# Altium schematic sheet Connectors.SchDoc
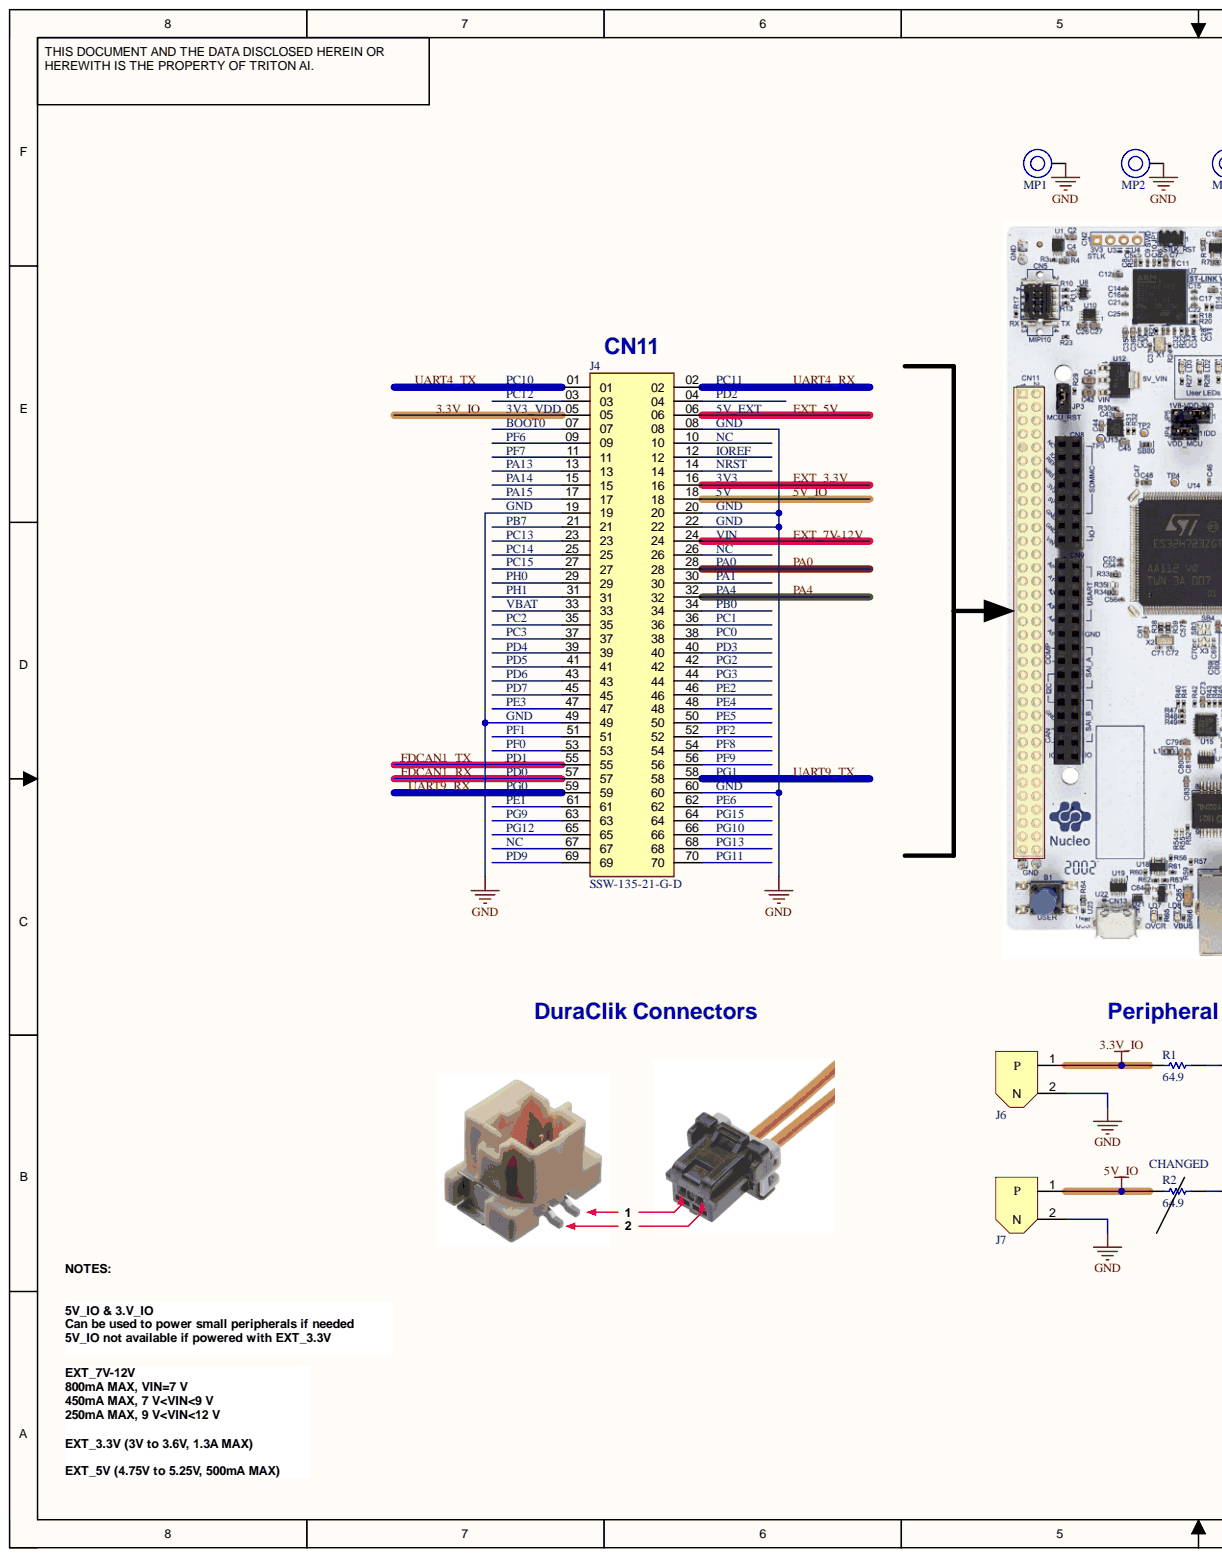
[diagram p0: Connectors.SchDoc, left]
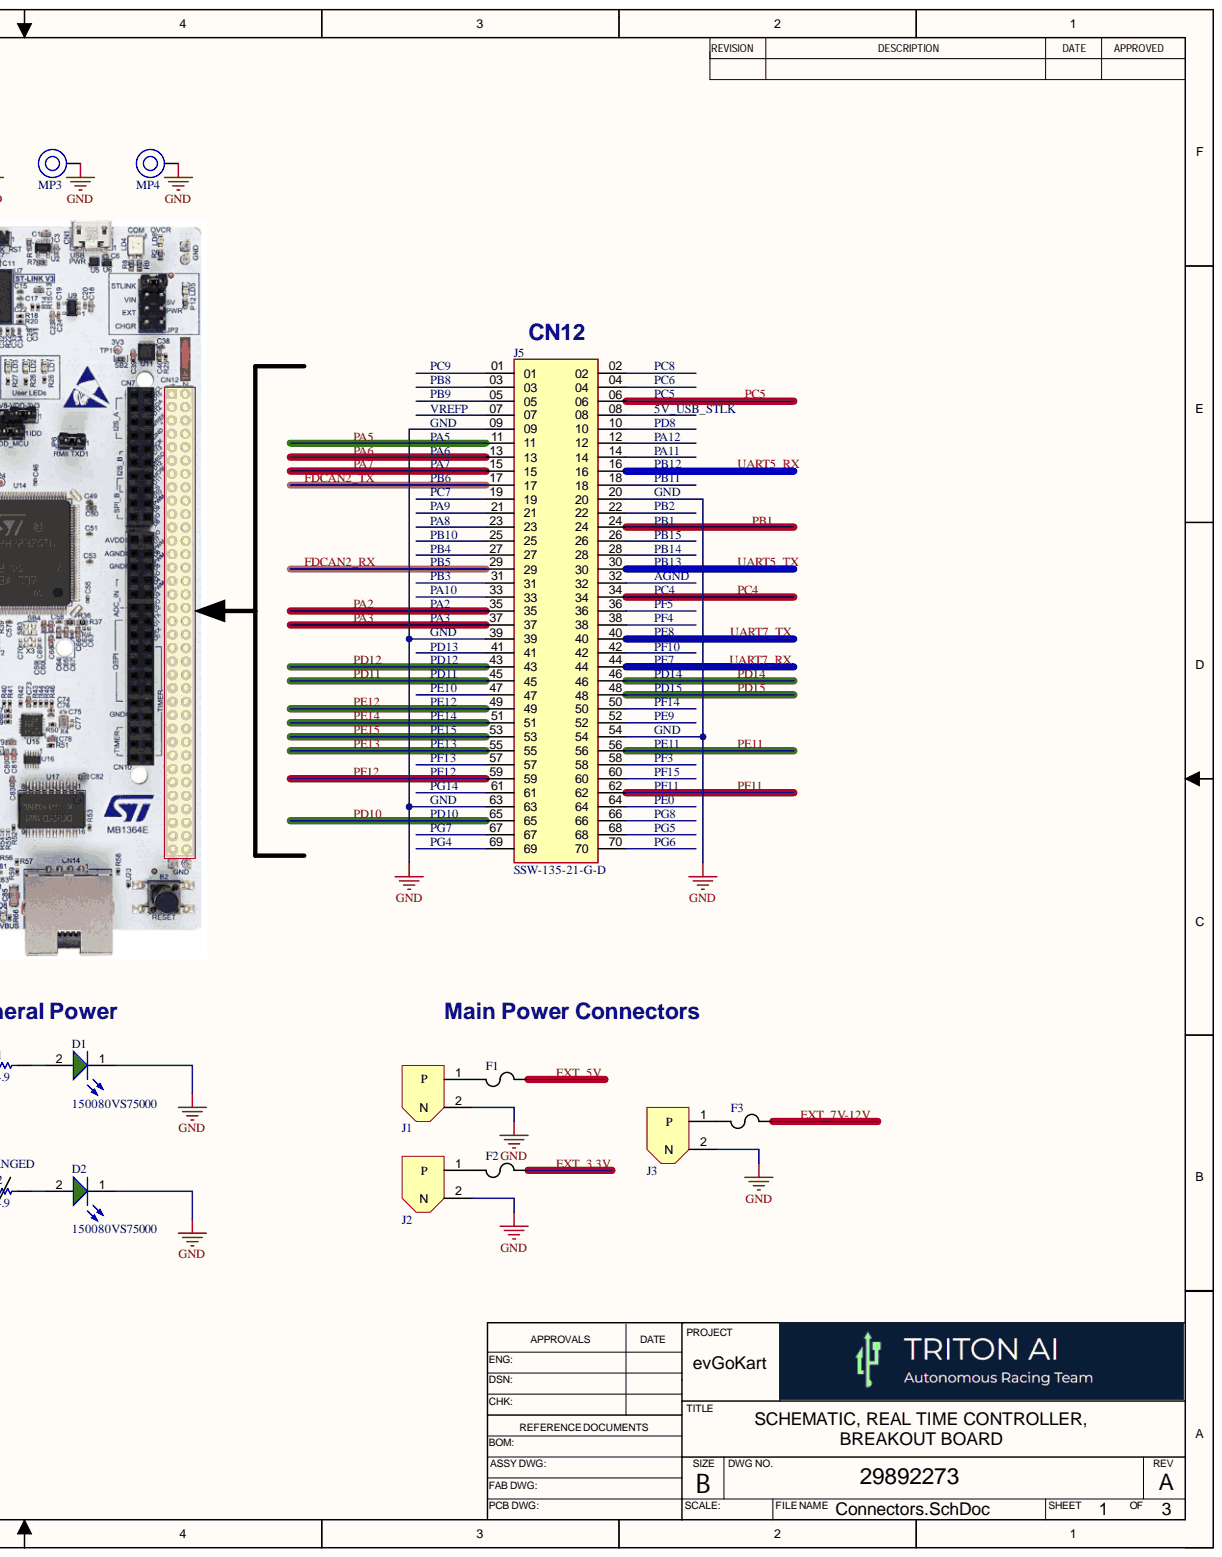
[diagram p1: Connectors.SchDoc, right]

## Parts
D1  150080VS75000  [WL-SMCW_0805_150080xx75000]
D2  150080VS75000  [WL-SMCW_0805_150080xx75000]
F1  500mA  [FUS_VISHAY_MFU0805_VIS]  (value not drawn)
F2  1.5A  [FUS_VISHAY_MFU0805_VIS]  (value not drawn)
F3  800mA  [FUS_VISHAY_MFU0805_VIS]  (value not drawn)
J1  XT30UPB-M  [XT30UPB-M]  (value not drawn)
J2  XT30UPB-M  [XT30UPB-M]  (value not drawn)
J3  XT30UPB-M  [XT30UPB-M]  (value not drawn)
J4  SSW-135-21-G-D  [SAMTEC_SSW-135-21-G-D]
J5  SSW-135-21-G-D  [SAMTEC_SSW-135-21-G-D]
J6  XT30UPB-M  [XT30UPB-M]  (value not drawn)
J7  XT30UPB-M  [XT30UPB-M]  (value not drawn)
MP1  M2.5 x 9mm  [9774090151]  (value not drawn)
MP2  M2.5 x 9mm  [9774090151]  (value not drawn)
MP3  M2.5 x 9mm  [9774090151]  (value not drawn)
MP4  M2.5 x 9mm  [9774090151]  (value not drawn)
R1  64.9  [RESC2013X60X35LL10T20]
R2  64.9  [RESC2013X60X35LL10T20]

## Nets
GND: D1.1 D2.1 J1.2 J2.2 J3.2 J4.08 J4.19 J4.20 J4.22 J4.49 J4.60 J5.09 J5.20 J5.39 J5.54 J5.63 J6.2 J7.2 MP1.1 MP2.1 MP3.1 MP4.1
3.3V_IO: J4.05 J6.1 R1.2
5V_IO: J4.18 J7.1 R2.2
EXT_3.3V: F2.1 J4.16
EXT_5V: F1.1 J4.06
EXT_7V-12V: F3.1 J4.24
FDCAN1_RX: J4.57
FDCAN1_TX: J4.55
FDCAN2_RX: J5.29
FDCAN2_TX: J5.17
PA0: J4.28
PA2: J5.35
PA3: J5.37
PA4: J4.32
PA5: J5.11
PA6: J5.13
PA7: J5.15
PB1: J5.24
PC4: J5.34
PC5: J5.06
PD10: J5.65
PD11: J5.45
PD12: J5.43
PD14: J5.46
PD15: J5.48
PE11: J5.56
PE12: J5.49
PE13: J5.55
PE14: J5.51
PE15: J5.53
PF11: J5.62
PF12: J5.59
UART4_RX: J4.02
UART4_TX: J4.01
UART5_RX: J5.16
UART5_TX: J5.30
UART7_RX: J5.44
UART7_TX: J5.40
UART9_RX: J4.59
UART9_TX: J4.58
NetD1_2: D1.2 R1.1
NetD2_2: D2.2 R2.1
NetF1_2: F1.2 J1.1
NetF2_2: F2.2 J2.1
NetF3_2: F3.2 J3.1

Not connected: J4 03 04 07 09 10 11 12 13 14 15 17 21 23 25 26 27 29 30 31 33 34 35 36 37 38 39 40 41 42 43 44 45 46 47 48 50 51 52 53 54 56 61 62 63 64 65 66 67 68 69 70; J5 01 02 03 04 05 07 08 10 12 14 18 19 21 22 23 25 26 27 28 31 32 33 36 38 41 42 47 50 52 57 58 60 61 64 66 67 68 69 70

## Library data of the parts
D1 D2 = CMP-1426-00009-6: WL-SMCW SMT Mono-Color Chip LED Waterclear; Color: Green; Dominant Wavelength: 570nm; Forward Current: 100mA; Forward Voltage: 2V; Lens Style: Clear, Rectangular; Luminous Intensity: 40mcd; Max Junction Temperature (Tj): 95°C; Max Reverse Voltage (DC): 5V; Peak Wavelength: 572nm; Power Dissipation: 72mW; Test Current: 20mA; Viewing Angle: 140°; Case Code (Imperial): 0805; Case Code (Metric): 2012; Case/Package: 0805; Component Kind: Standard; Height: 700µm; Length: 2mm; Library Name: Altium Content Vault; Max Operating Temperature: 85°C; Min Operating Temperature: -40°C; Mount: Surface Mount; Packaging: Tape and Reel; REACH SVHC: Unknown; Reverse Voltage: 5V; RoHS: Compliant; Wavelength: 570nm; Width: 1.25mm
F1 = MFU0805FF00500P500: Mfr_Name: Vishay; Component Kind: Standard; Current Rating: 500mA; Description: MFU0805FF00500P500; Library Name: MFU0805FF00500P500.SchLib
F2 = MFU0805FF01500P100: Mfr_Name: Vishay; Component Kind: Standard; Current Rating: 1.5A; Description: MFU0805FF01500P100; Library Name: MFU0805FF01500P100.SchLib
F3 = MFU0805FF00800P100: Mfr_Name: Vishay; Component Kind: Standard; Current Rating: 800mA; Description: MFU0805FF00800P100; Library Name: MFU0805FF00800P100.SchLib
J1-J3 J6 J7 = XT30UPB-M: Socket; DC supply; XT30; male; PIN: 2; on PCBs; THT; yellow; 15A; 500V; MF: amass; Availability: Not in stock; Check_prices: https://www.snapeda.com/parts/XT30UPB-M/AMASS/view-part/?ref=eda; Component Kind: Standard; Library Name: XT30UPB-M.SchLib; MP: XT30UPB-M; Package: None; Price: None; SnapEDA_Link: https://www.snapeda.com/parts/XT30UPB-M/AMASS/view-part/?ref=snap
J4 J5 = SSW-135-21-G-D: MF: {'manufacturer': u'Samtec Inc.'}; Availability: Unavailable; Component Kind: Standard; Library Name: SSW-135-21-G-D.SchLib; MP: SSW-135-21-G-D; Package: None; Price: None
MP1-MP4 = 9774090151R: WA-SMSI SMT Steel Spacer with internal Thread, OD 5.1mm, M2.5 x 9mm; Manufacturer: Wurth Elektronik; Inside Diameter: 3.5mm; Surface: Tin; Category: SMT Spacers; Component Kind: Standard; ComponentLink1Description: Website Link; ComponentLink1URL: https://www.we-online.com/redexpert/article/9774090151R?ad; ComponentLink2Description: Datasheet Link; ComponentLink2URL: https://www.we-online.com/redexpert/spec/9774090151R?ad; Height: 9mm; Library Name: Altium_WA-SMSI_rev22a.IntLib; Manufacturer Part Number: 9774090151R; Match Code: WA-SMSI; Material: Steel; Mount: Surface Mount; Operating Temperature Max: 150°C; Operating Temperature Min: -55°C; Outside Diameter: 5.1mm; Packaging: Tape and Reel; Thread Size: M2.5
R1 R2 = CMP-2001-01223-1: Manufacturer: Yageo; Part Number: RC0805FR-0764R9L; Component Kind: Standard; Library Name: Altium Content Vault
